# Altium schematic sheet MCU_REV2.SchDoc(MCU_REV2)
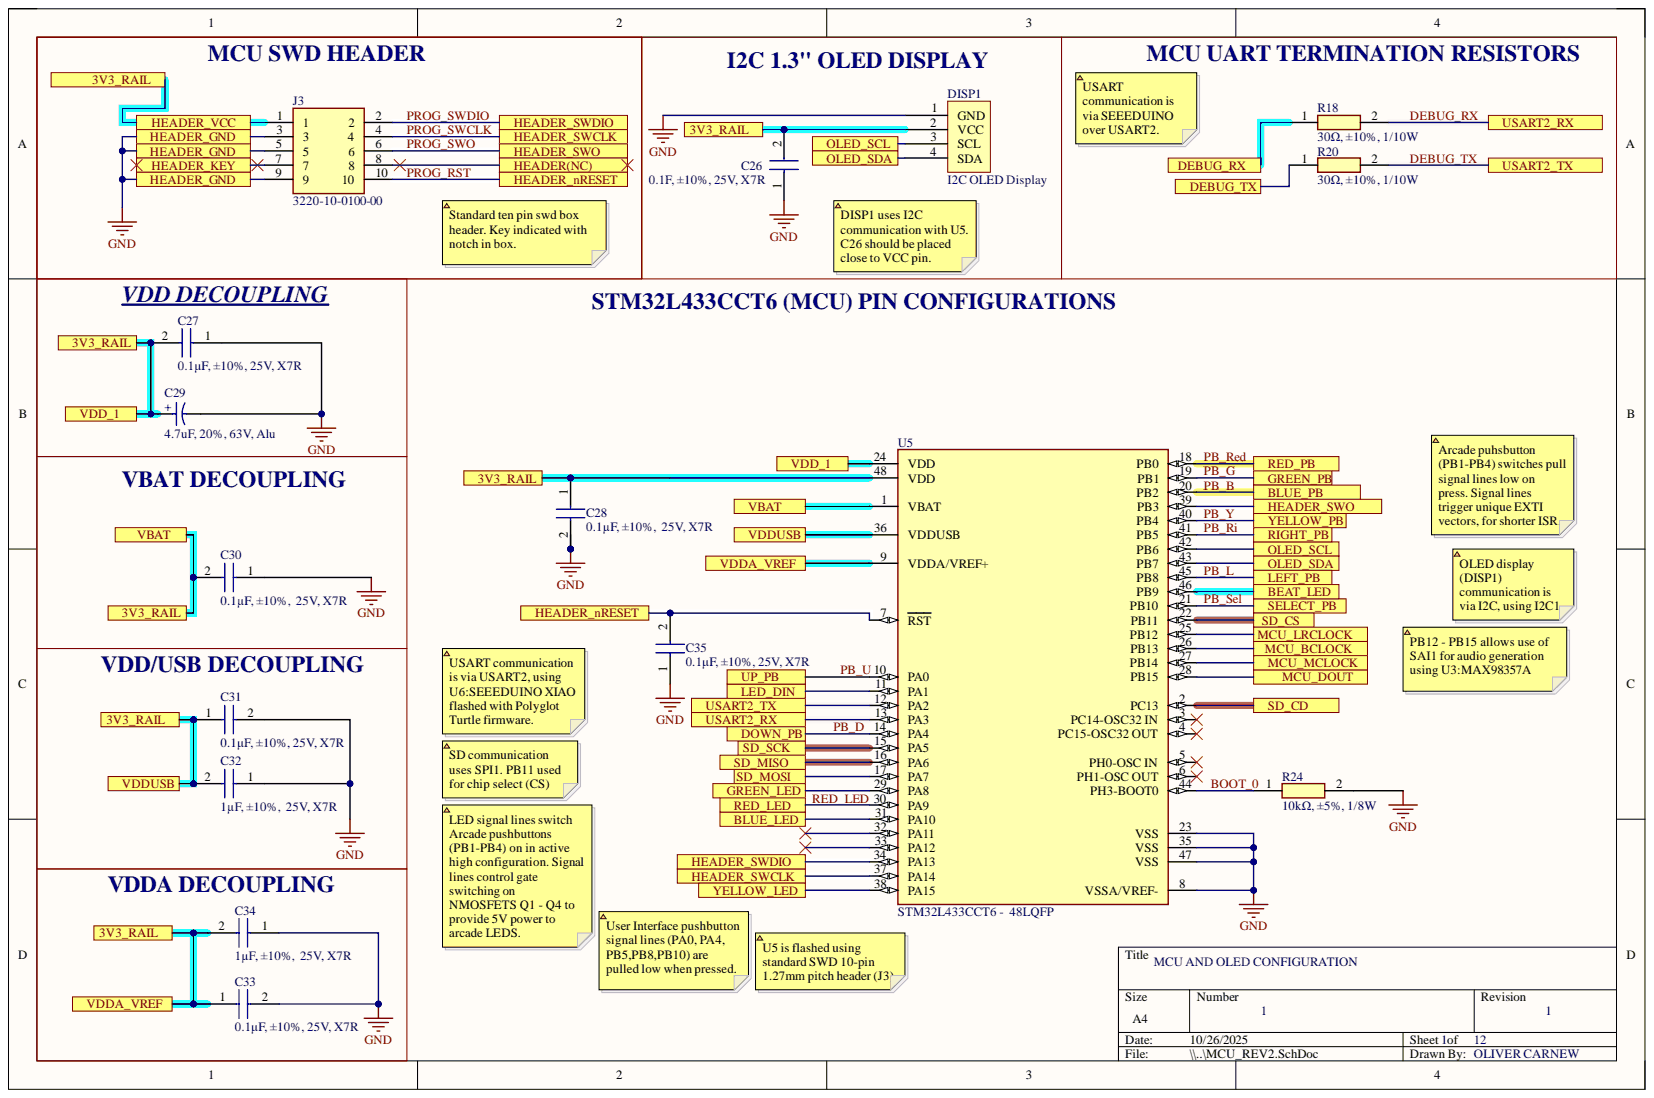
[diagram p0: MCU_REV2.SchDoc]

## Parts
C26  0.1F, ±10%, 25V, X7R  [CAPC1005X55N]
C27  0.1μF, ±10%, 25V, X7R  [CAPC1005X55N]
C28  0.1µF, ±10%,  25V, X7R  [CAPC1005X55N]
C29  4.7uF, 20%, 63V, Alu  [WCAP-ATG5_D5H11]
C30  0.1µF, ±10%,  25V, X7R  [CAPC1005X55N]
C31  0.1μF, ±10%, 25V, X7R  [CAPC1005X55N]
C32  1µF, ±10%,  25V, X7R  [CAPC1608X90N]
C33  0.1μF, ±10%, 25V, X7R  [CAPC1005X55N]
C34  1µF, ±10%,  25V, X7R  [CAPC1608X90N]
C35  0.1μF, ±10%, 25V, X7R  [CAPC1005X55N]
DISP1  I2C OLED Display  [OLED_I2C_4pinHEADER]
J3  3220-10-0100-00  [322010010000]
R18  30Ω, ±10%, 1/10W  [RESC1608X55N]
R20  30Ω, ±10%, 1/10W  [RESC1608X55N]
R24  10kΩ, ±5%, 1/8W  [RESC2012X60N]
U5  STM32L433CCT6 -  48LQFP  Manufacturer Part Number: STM32L433CCT6  [FP-LQFP48-5B-MFG]

## Ports
3V3_RAIL, BEAT_LED, BLUE_LED, BLUE_PB, DEBUG_RX, DEBUG_TX, DOWN_PB, GREEN_LED, GREEN_PB, HEADER(NC), HEADER_GND, HEADER_KEY, HEADER_nRESET, HEADER_SWCLK, HEADER_SWDIO, HEADER_SWO, HEADER_VCC, LED_DIN, LEFT_PB, MCU_BCLOCK, MCU_DOUT, MCU_LRCLOCK, MCU_MCLOCK, OLED_SCL, OLED_SDA, RED_LED, RED_PB, RIGHT_PB, SD_CD, SD_CS, SD_MISO, SD_MOSI, SD_SCK, SELECT_PB, UP_PB, USART2_RX, USART2_TX, VBAT, VDD_1, VDDA_VREF, VDDUSB, YELLOW_LED, YELLOW_PB

## Nets
3V3_RAIL: C26.2 C27.2 C28.1 C29.1 C30.2 C31.1 C32.2 C33.1 C34.2 DISP1.2(VCC) J3.1 U5.1(VBAT) U5.9(VDDA/VREF+) U5.24(VDD) U5.36(VDDUSB) U5.48(VDD) ; port 3V3_RAIL, port HEADER_VCC, port VBAT, port VDDA_VREF, port VDDUSB, port VDD_1
BEAT_LED: U5.46(PB9) ; port BEAT_LED
BLUE_LED: U5.31(PA10) ; port BLUE_LED
BOOT_0: R24.1 U5.44(PH3-BOOT0)
DEBUG_RX: R18.2 U5.13(PA3) ; port USART2_RX
DEBUG_TX: R20.2 U5.12(PA2) ; port USART2_TX
GND: C26.1 C27.1 C28.2 C29.2 C30.1 C31.2 C32.1 C33.2 C34.1 C35.1 DISP1.1(GND) J3.3 J3.5 J3.9 R24.2 U5.8(VSSA/VREF-) U5.23(VSS) U5.35(VSS) U5.47(VSS) ; port HEADER_GND
GREEN_LED: U5.29(PA8) ; port GREEN_LED
HEADER(NC): J3.8 ; port HEADER(NC)
HEADER_KEY: J3.7 ; port HEADER_KEY
LED_DIN: U5.11(PA1) ; port LED_DIN
MCU_BCLOCK: U5.26(PB13) ; port MCU_BCLOCK
MCU_DOUT: U5.28(PB15) ; port MCU_DOUT
MCU_LRCLOCK: U5.25(PB12) ; port MCU_LRCLOCK
MCU_MCLOCK: U5.27(PB14) ; port MCU_MCLOCK
OLED_SCL: DISP1.3(SCL) U5.42(PB6) ; port OLED_SCL
OLED_SDA: DISP1.4(SDA) U5.43(PB7) ; port OLED_SDA
PB_B: U5.20(PB2) ; port BLUE_PB
PB_D: U5.14(PA4) ; port DOWN_PB
PB_G: U5.19(PB1) ; port GREEN_PB
PB_L: U5.45(PB8) ; port LEFT_PB
PB_Red: U5.18(PB0) ; port RED_PB
PB_Ri: U5.41(PB5) ; port RIGHT_PB
PB_Sel: U5.21(PB10) ; port SELECT_PB
PB_U: U5.10(PA0) ; port UP_PB
PB_Y: U5.40(PB4) ; port YELLOW_PB
PROG_RST: C35.2 J3.10 U5.7(RST) ; port HEADER_nRESET
PROG_SWCLK: J3.4 U5.37(PA14) ; port HEADER_SWCLK
PROG_SWDIO: J3.2 U5.34(PA13) ; port HEADER_SWDIO
PROG_SWO: J3.6 U5.39(PB3) ; port HEADER_SWO
RED_LED: U5.30(PA9) ; port RED_LED
SD_CD: U5.2(PC13) ; port SD_CD
SD_CS: U5.22(PB11) ; port SD_CS
SD_MISO: U5.16(PA6) ; port SD_MISO
SD_MOSI: U5.17(PA7) ; port SD_MOSI
SD_SCK: U5.15(PA5) ; port SD_SCK
YELLOW_LED: U5.38(PA15) ; port YELLOW_LED
NetR18_1: R18.1 ; port DEBUG_RX
NetR20_1: R20.1 ; port DEBUG_TX

Not connected: U5 3(PC14-OSC32_IN) 4(PC15-OSC32_OUT) 5(PH0-OSC_IN) 6(PH1-OSC_OUT) 32(PA11) 33(PA12)

## Library data of the parts
C26-C28 C30 C31 C33 C35 = CL05B104KA5NNNC: Capacitor CAP CER 0.1UF 25V X7R 0402 CL05B104KA5NNNC; Manufacturer_Name: SAMSUNG; Arrow Part Number: CL05B104KA5NNNC; Arrow Price/Stock: https://www.arrow.com/en/products/cl05b104ka5nnnc/samsung-electro-mechanics?region=nac; Component Kind: Standard; Datasheet Link: https://datasheet.datasheetarchive.com/originals/distributors/DKDS-19/372989.pdf; Height: 0.55mm; Manufacturer_Part_Number: CL05B104KA5NNNC; Mouser Part Number: 187-CL05B104KA5NNNC; Mouser Price/Stock: https://www.mouser.co.uk/ProductDetail/Samsung-Electro-Mechanics/CL05B104KA5NNNC?qs=Efv9o2T6U88DLyyMSzjXSQ%3D%3D; Parameter 1: Capacitor CAP CER 0.1UF 25V X7R 0402 CL05B104KA5NNNC
C29 = CMP-03913-53914231-1: 860020672008 WCAP-ATG5 Aluminum Electrolytic Capacitors860020672008; Capacitance: 4.7µF; Leakage Current: 3µA; Life (Hours): 2000hours; Pitch: 2mm; Polarity: Polar; Ripple Current: 32mA; Voltage Rating: 50V; Case/Package: Radial; Component Kind: Standard; Diameter: 5mm; Height: 11mm; Height - Seated (Max): 12.4968mm; Lead Pitch: 2.0066mm; Length: 11mm; Library Name: Altium Content Vault; Max Operating Temperature: 105°C; Min Operating Temperature: -40°C; Mount: Through Hole; NexarPartId: 53914231; Packaging: Tape & Box (TB); REACH SVHC: Unknown; RoHS: Compliant; Tolerance: 20%; Voltage Rating (DC): 50V
C32 C34 = CL10B105KA8NNNC: Capacitor 1 µF ±10% 25V Ceramic Capacitor X7R 0603 (1608 Metric) CL10B105KA8NNNC; Manufacturer_Name: SAMSUNG; Arrow Part Number: CL10B105KA8NNNC; Arrow Price/Stock: https://www.arrow.com/en/products/cl10b105ka8nnnc/samsung-electro-mechanics?region=nac; Component Kind: Standard; Datasheet Link: https://datasheet.datasheetarchive.com/originals/distributors/Datasheets-DGA10/2410489.pdf; Height: 0.9mm; Manufacturer_Part_Number: CL10B105KA8NNNC; Mouser Part Number: 187-CL10B105KA8NNNC; Mouser Price/Stock: https://www.mouser.co.uk/ProductDetail/Samsung-Electro-Mechanics/CL10B105KA8NNNC?qs=349EhDEZ59qp%252BMgnTol5Nw%3D%3D; Parameter 1: 1 µF ±10% 25V Ceramic Capacitor X7R 0603 (1608 Metric)
DISP1 = OLED_I2C_4pinHEADER: OLED_I2C_4pinHEADER Large clear space; Component Kind: Standard; Library Name: DANCE_LIB_REV2.SchLib
J3 = 3220-10-0100-00: Connector; Manufacturer_Name: CNC Tech; Arrow Part Number: 3220-10-0100-00; Arrow Price/Stock: https://www.arrow.com/en/products/3220-10-0100-00/cnc-tech-llc?utm_currency=USD&region=nac; Component Kind: Standard; Datasheet Link: https://datasheet.datasheetarchive.com/originals/dk/DKDS-7/133059.pdf; Height: 5.6mm; Manufacturer_Part_Number: 3220-10-0100-00
R18 R20 = ERJPA3F30R0V: Resistor ERJPA3F30R0V 30 Ohms ±1% 0.333W, 1/3W Chip Resistor 0603 (1608 Metric) Automotive AEC-Q200, Pulse Withstanding Thick Film; Manufacturer_Name: Panasonic; Arrow Part Number: ERJPA3F30R0V; Arrow Price/Stock: https://www.arrow.com/en/products/erj-pa3f30r0v/panasonic; Component Kind: Standard; Datasheet Link: https://industrial.panasonic.com/cdbs/www-data/pdf/RDA0000/AOA0000C243.pdf; Height: 0.55mm; Manufacturer_Part_Number: ERJPA3F30R0V; Parameter 1: Resistor ERJPA3F30R0V 30 Ohms ±1% 0.333W, 1/3W Chip Resistor 0603 (1608 Metric) Automotive AEC-Q200, Pulse Withstanding Thick Film
R24 = RC0805JR-0710KL: Resistor RES 10K OHM 5% 1/8W 0805  RC0805JR-0710KL; Manufacturer_Name: YAGEO; Arrow Part Number: RC0805JR-0710KL; Arrow Price/Stock: https://www.arrow.com/en/products/rc0805jr-0710kl/yageo?region=nac; Component Kind: Standard; Datasheet Link: https://componentsearchengine.com/Datasheets/2/RC0805JR-0710KL.pdf; Height: 0.6mm; Manufacturer_Part_Number: RC0805JR-0710KL; Mouser Part Number: 603-RC0805JR-0710KL; Mouser Price/Stock: https://www.mouser.co.uk/ProductDetail/YAGEO/RC0805JR-0710KL?qs=ZFGQJeMQzF5q%2Fp77vM%2FrVQ%3D%3D
U5 = CMP-12105-000047-1: IC MCU 32BIT 256KB FLASH 48LQFP; Manufacturer: STMicroelectronics; Core Architecture: Arm Cortex-M4; Data Bus Width: 32bits; Frequency: 80MHz; Interface: I2C,SPI,UART,USART,CAN,USB,LIN,IrDA,SMBus,Other; Max Junction Temp: +105°C; Max Supply Voltage: 3.6V; Memory Size: 256KBytes; Min Supply Voltage: 1.55V; Number Of A/D Converters: 1; Number Of Adc Channels: 10; Number Of I2C Channels: 3; Number Of I/Os: 38; Number Of Pwm Channels: 14; Number Of Timers/Counters: 9; Number Of Uart Channels: 4; Ram Size: 64KBytes; Standoff Height: 0.05mm; Ambient Temperature Range High: +85°C; Ambient Temperature Range Low: -40°C; Automotive: No; Category: IC; Component Kind: Standard; ComponentLink2Description: Datasheet; ComponentLink2URL: https://www.st.com/resource/en/datasheet/stm32l433cc.pdf; Device Class L1: Integrated Circuits (ICs); Device Class L2: Embedded Processors and Controllers; Device Class L3: Microcontrollers; Digikey Description: IC MCU 32BIT 256KB FLASH 48LQFP; Height: 1.6mm; Ipc Land Pattern Name: QFP50P900X900X160-48; Lead Free: Yes; Library Name: Altium Content Vault; Memory Type: FLASH; Number Of Cores: 1; Number Of Spi Channels: 3; Number Of Usb Channels: 1; Octopart: https://octopart.com/stm32l433cct6-stmicroelectronics-71093511; Package: LQFP48-5B; RoHS: TRUE; Temperature: -40°C to +85°C; Temperature Range High: +85°C; Temperature Range Low: -40°C
